# Altium schematic sheet PIXRACER-IMU-V1-R15.SchDoc(PIXRACER-IMU-V1-R15)
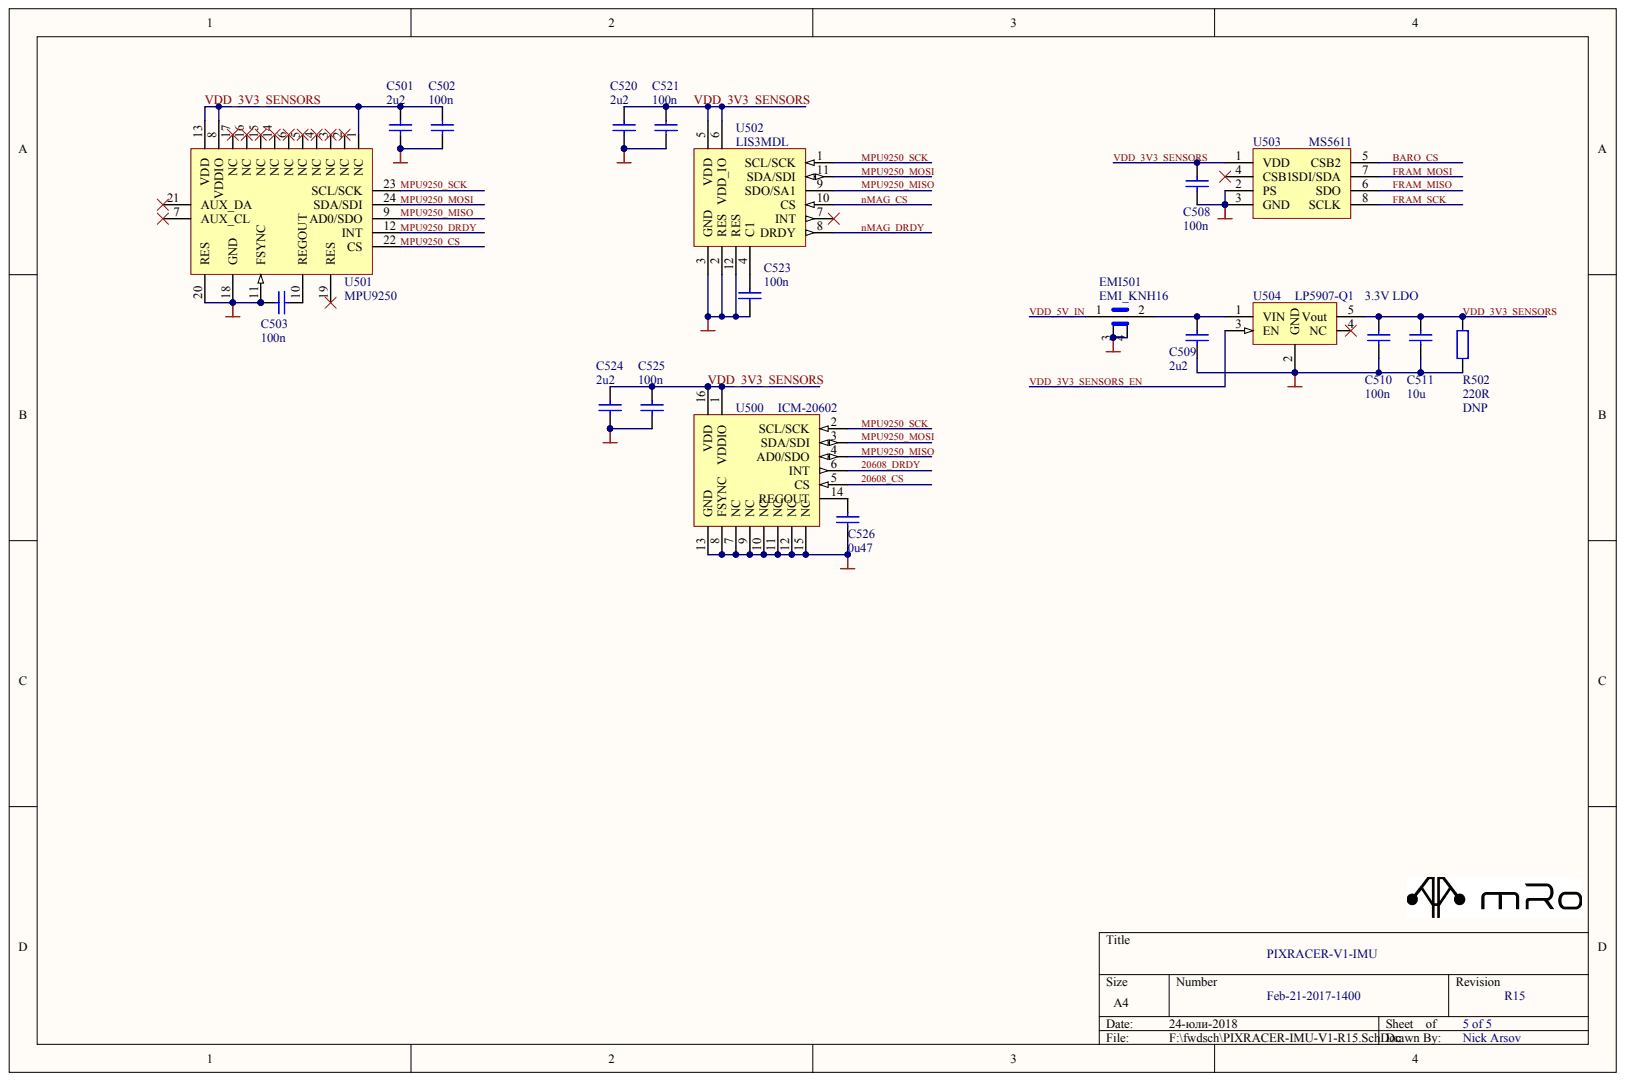
[diagram p0: PIXRACER-IMU-V1-R15.SchDoc]

## Parts
C501  2u2
C502  100n
C503  100n
C508  100n
C509  2u2
C510  100n
C511  10u
C520  2u2
C521  100n
C523  100n
C524  2u2
C525  100n
C526  0u47
EMI501  EMI_KNH16
R502  220R
U500  ICM-20602
U501  MPU9250
U502  LIS3MDL
U503  MS5611
U504  LP5907-Q1

## Nets
GND: C501.1 C502.1 C503.1 C508.1 C509.1 C510.1 C511.1 C520.1 C521.1 C523.2 C524.1 C525.1 C526.1 EMI501.3 EMI501.4 R502.1 U500.7(NC) U500.8(FSYNC) U500.9(NC) U500.10(NC) U500.11(NC) U500.12(NC) U500.13(GND) U500.15(NC) U501.11(FSYNC) U501.18(GND) U501.20(RES) U502.2(RES) U502.3(GND) U502.12(RES) U503.2(PS) U503.3(GND) U504.2(GND)
20608_CS: U500.5(CS)
20608_DRDY: U500.6(INT)
BARO_CS: U503.5(CSB2)
FRAM_MISO: U503.6(SDO)
FRAM_MOSI: U503.7(SDI/SDA)
FRAM_SCK: U503.8(SCLK)
MPU9250_CS: U501.22(CS)
MPU9250_DRDY: U501.12(INT)
MPU9250_MISO: U500.4(AD0/SDO) U501.9(AD0/SDO) U502.9(SDO/SA1)
MPU9250_MOSI: U500.3(SDA/SDI) U501.24(SDA/SDI) U502.11(SDA/SDI)
MPU9250_SCK: U500.2(SCL/SCK) U501.23(SCL/SCK) U502.1(SCL/SCK)
NMAG_CS: U502.10(CS)
NMAG_DRDY: U502.8(DRDY)
VDD_3V3_SENSORS: C501.2 C502.2 C508.2 C510.2 C511.2 C520.2 C521.2 C524.2 C525.2 R502.2 U500.1(VDDIO) U500.16(VDD) U501.1(NC) U501.8(VDDIO) U501.13(VDD) U502.5(VDD) U502.6(VDD_IO) U503.1(VDD) U504.5(Vout)
VDD_3V3_SENSORS_EN: U504.3(EN)
VDD_5V_IN: EMI501.1
NetC503_2: C503.2 U501.10(REGOUT)
NetC509_2: C509.2 EMI501.2 U504.1(VIN)
NetC523_1: C523.1 U502.4(C1)
NetC526_2: C526.2 U500.14(REGOUT)

Not connected: U501 2(NC) 3(NC) 4(NC) 5(NC) 6(NC) 7(AUX_CL) 14(NC) 15(NC) 16(NC) 17(NC) 19(RES) 21(AUX_DA); U502 7(INT); U503 4(CSB1); U504 4(NC)

## Library data of the parts
C501-C503 C508-C511 C520 C521 C523-C526 = (no symbol name): Value: 100pF; Published: 8-Jun-2000; LatestRevisionDate: 17-Jul-2002; LatestRevisionNote: Re-released for DXP Platform.; PackageReference: RAD-0.3; Publisher: Altium Limited
R502 = (no symbol name): Value: 1K; REM: DNP; Published: 8-Jun-2000; LatestRevisionDate: 17-Jul-2002; LatestRevisionNote: Re-released for DXP Platform.; PackageReference: AXIAL-0.4; PackageDescription: Axial Device, Thru-Hole; 2 Leads; 0.4 in Pin Spacing; Publisher: Altium Limited
U504 = (no symbol name): REM: 3.3V LDO
